# Altium schematic sheet Core.SchDoc
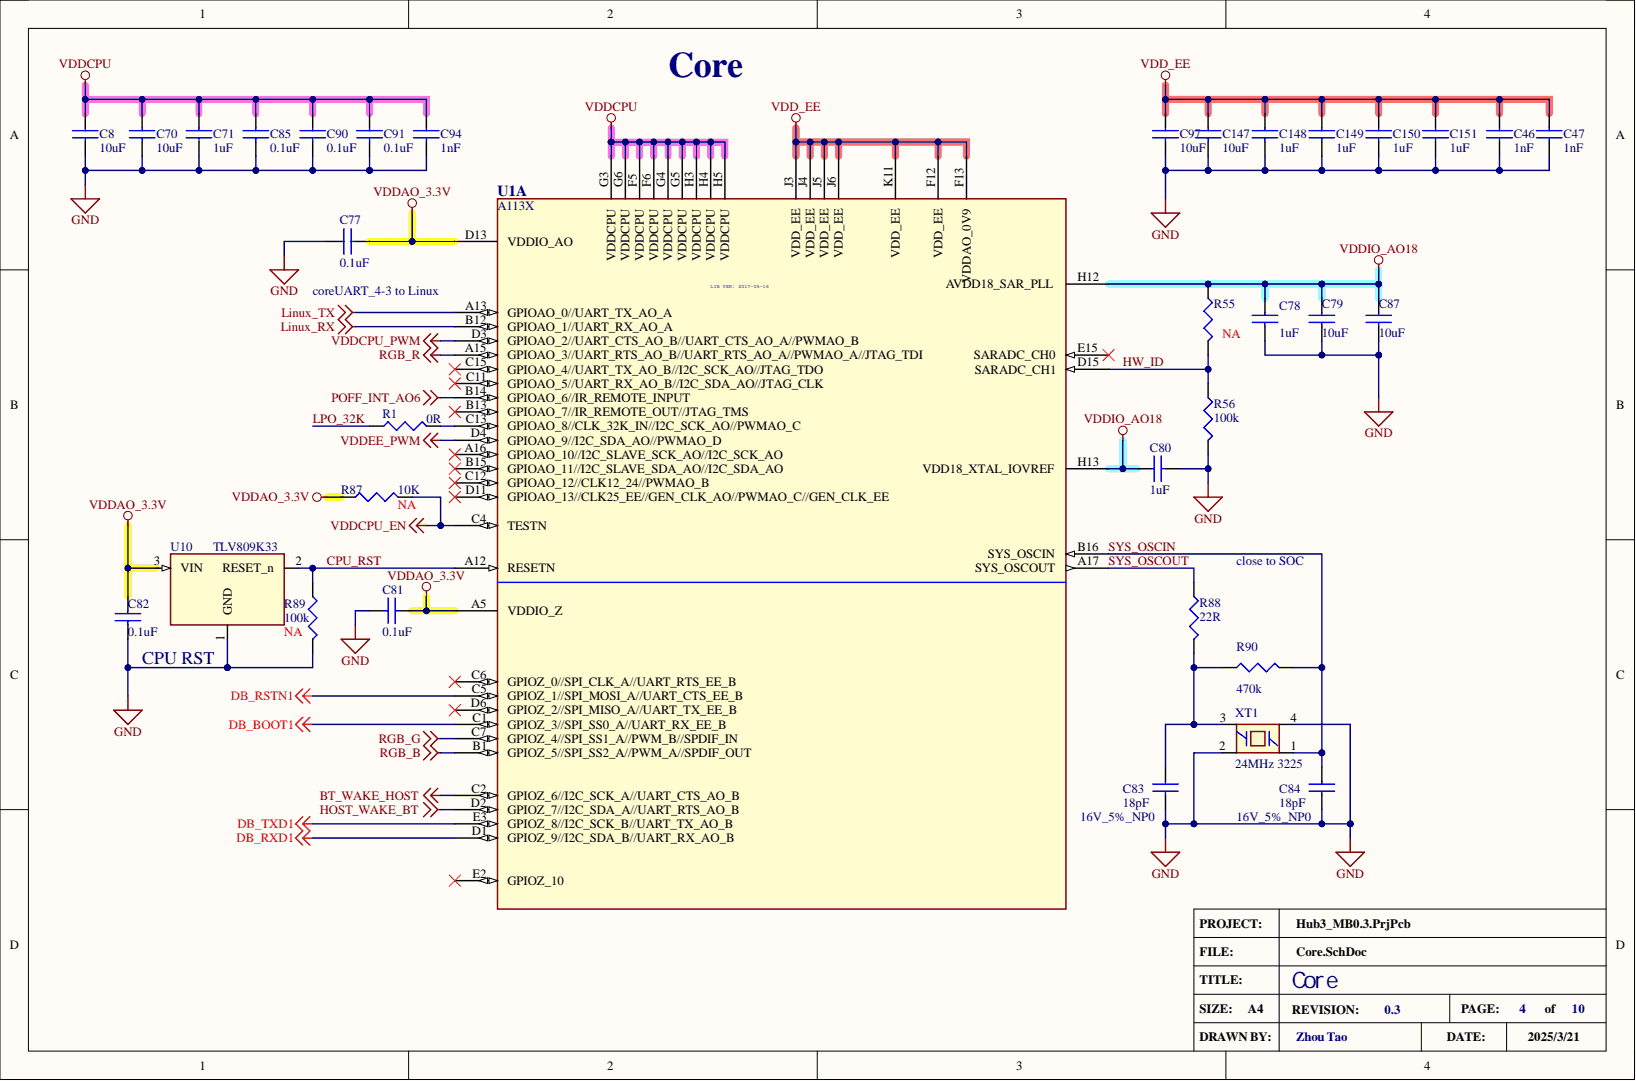
[diagram p0: Core.SchDoc]

## Parts
C8  10uF  [C0603]
C46  1nF  [C0201]
C47  1nF  [C0201]
C70  10uF  [C0603]
C71  1uF  [C0201]
C77  0.1uF  [C0201]
C78  1uF  [C0201]
C79  10uF  [C0603]
C80  1uF  [C0201]
C81  0.1uF  [C0201]
C82  0.1uF  [C0201]
C83  18pF  [C0402]
C84  18pF  [C0402]
C85  0.1uF  [C0201]
C87  10uF  [C0603]
C90  0.1uF  [C0201]
C91  0.1uF  [C0201]
C94  1nF  [C0201]
C97  10uF  [C0603]
C147  10uF  [C0603]
C148  1uF  [C0201]
C149  1uF  [C0201]
C150  1uF  [C0201]
C151  1uF  [C0201]
R1  0R  [R0201]
R55  NA  [R0402]  (no BOM)
R56  100k  [R0402]
R87  NA  value 10K  [R0201]  (no BOM)
R88  22R  [R0201]
R89  NA  value 100k  [R0402]  (no BOM)
R90  value 470k  [R0402]  (hidden comment: RES_470k_0402_1%)
U1  A113X  [A113X_284]  (part A)
U10  TLV809K33  [SOT-23]
XT1  24MHz 3225  [XT-SMD4P3225]

## Nets
BT_WAKE_HOST: U1.C2(GPIOZ_6//I2C_SCK_A//UART_CTS_AO_B)
DB_BOOT1: U1.C1(GPIOZ_3//SPI_SS0_A//UART_RX_EE_B)
DB_RSTN1: U1.C5(GPIOZ_1//SPI_MOSI_A//UART_CTS_EE_B)
DB_RXD1: U1.D1(GPIOZ_9//I2C_SDA_B//UART_RX_AO_B)
DB_TXD1: U1.E3(GPIOZ_8//I2C_SCK_B//UART_TX_AO_B)
GND: C8.2 C46.2 C47.2 C70.2 C71.2 C77.1 C78.2 C79.2 C80.2 C81.2 C82.2 C83.2 C84.2 C85.2 C87.2 C90.2 C91.2 C94.2 C97.2 C147.2 C148.2 C149.2 C150.2 C151.2 R56.2 R89.2 U10.1 XT1.2 XT1.4
HOST_WAKE_BT: U1.D2(GPIOZ_7//I2C_SDA_A//UART_RTS_AO_B)
Linux_RX: U1.B12(GPIOAO_1//UART_RX_AO_A)
Linux_TX: U1.A13(GPIOAO_0//UART_TX_AO_A)
POFF_INT_AO6: U1.B14(GPIOAO_6//IR_REMOTE_INPUT)
RGB_B: U1.B1(GPIOZ_5//SPI_SS2_A//PWM_A//SPDIF_OUT)
RGB_G: U1.C7(GPIOZ_4//SPI_SS1_A//PWM_B//SPDIF_IN)
RGB_R: U1.A15(GPIOAO_3//UART_RTS_AO_B//UART_RTS_AO_A//PWMAO_A//JTAG_TDI)
VDD_EE: C46.1 C47.1 C97.1 C147.1 C148.1 C149.1 C150.1 C151.1 U1.F12(VDD_EE) U1.F13(VDDAO_0V9) U1.J3(VDD_EE) U1.J4(VDD_EE) U1.J5(VDD_EE) U1.J6(VDD_EE) U1.K11(VDD_EE)
VDDAO_3.3V: C77.2 C81.1 C82.1 R87.1 U1.A5(VDDIO_Z) U1.D13(VDDIO_AO) U10.3
VDDCPU: C8.1 C70.1 C71.1 C85.1 C90.1 C91.1 C94.1 U1.F5(VDDCPU) U1.F6(VDDCPU) U1.G3(VDDCPU) U1.G4(VDDCPU) U1.G5(VDDCPU) U1.G6(VDDCPU) U1.H3(VDDCPU) U1.H4(VDDCPU) U1.H5(VDDCPU)
VDDCPU_EN: R87.2 U1.C4(TESTN)
VDDCPU_PWM: U1.D3(GPIOAO_2//UART_CTS_AO_B//UART_CTS_AO_A//PWMAO_B)
VDDEE_PWM: U1.D4(GPIOAO_9//I2C_SDA_AO//PWMAO_D)
VDDIO_AO18: C78.1 C79.1 C80.1 C87.1 R55.1 U1.H12(AVDD18_SAR_PLL) U1.H13(VDD18_XTAL_IOVREF)
CPU_RST: R89.1 U1.A12(RESETN) U10.2
HW_ID: R55.2 R56.1 U1.D15(SARADC_CH1)
LPO_32K: R1.2
SYS_OSCIN: C84.1 R90.2 U1.B16(SYS_OSCIN) XT1.1
SYS_OSCOUT: R88.1 U1.A17(SYS_OSCOUT)
NetC83_1: C83.1 R88.2 R90.1 XT1.3
NetR1_1: R1.1 U1.C13(GPIOAO_8//CLK_32K_IN//I2C_SCK_AO//PWMAO_C)

Not connected: U1 A16(GPIOAO_10//I2C_SLAVE_SCK_AO//I2C_SCK_AO) B13(GPIOAO_7//IR_REMOTE_OUT//JTAG_TMS) B15(GPIOAO_11//I2C_SLAVE_SDA_AO//I2C_SDA_AO) C6(GPIOZ_0//SPI_CLK_A//UART_RTS_EE_B) C11(GPIOAO_5//UART_RX_AO_B//I2C_SDA_AO//JTAG_CLK) C12(GPIOAO_12//CLK12_24//PWMAO_B) C15(GPIOAO_4//UART_TX_AO_B//I2C_SCK_AO//JTAG_TDO) D6(GPIOZ_2//SPI_MISO_A//UART_TX_EE_B) D11(GPIOAO_13//CLK25_EE//GEN_CLK_AO//PWMAO_C//GEN_CLK_EE) E2(GPIOZ_10) E15(SARADC_CH0)

## Library data of the parts
C8 C70 C79 C87 C97 C147 = Cap_10uF_0603_10%_10V: Manufacturer: Samsung; Part Number: CL10A106KP8NNNC; Des: 10uF,0603,X5R, 10%, 10V; Component Kind: Standard; value: 10uF; Library Name: Lib_sch_Hubv3_2332.SchLib
C46 C47 C94 = Cap_1nF_0201_50V: Manufacturer: EYANG; Part Number: C0201X7R102K500NTA; Des: 1nF,0201,50V,X7R,10%; Component Kind: Standard; value: 1nF; Library Name: Lib_sch_Hubv3_2332.SchLib
C71 C78 C80 C148-C151 = Cap_1uF_0201_6.3V: Manufacturer: Walsin Tech; Part Number: 0201X105K6R3CT; Des: 1uF,0201,6.3V,10%,X5R; Component Kind: Standard; value: 1uF; Library Name: Lib_sch_Hubv3_2332.SchLib
C77 C81 C82 C85 C90 C91 = Cap_0.1uF_0201_16V: Manufacturer: EYANG; Part Number: C0201X5R104K160NTA; Des: 0.1uF,0201,16V,X5R,10%; Component Kind: Standard; value: 0.1uF; Library Name: Lib_sch_Hubv3_2332.SchLib
C83 C84 = Cap_18pF_0402_16V_5%: Manufacturer: KEMET; Part Number: C0402C180J4GAC7867; Des: 18pF,0402,16V,5%,NP0,low ESL; Component Kind: Standard; value: 18pF; Library Name: Lib_sch_spk_V0_180613.SchLib
R1 = RES_0R_0201: Manufacturer: Yageo; part number: RC0201JR-070RL; Des: 0R,0201,1/20w; Component Kind: Standard; value: 0R; Library Name: Lib_sch_spk_V1_2020604.SchLib
R55 = RES_0402_NA: value: NA; Library Name: Lib_sch_spk_V1_2020604.SchLib
R56 R89 = RES_100k_0402_1%: Manufacturer: Yageo; part number: RC0402FR-07100KL; Des: 100k,0402,1%,1/16w
  R56: Component Kind: Standard; value: 100k; Library Name: Lib_sch_spk_V1_2020604.SchLib
  R89: Library Name: Lib_sch_spk_V0_180613.SchLib
R87 = RES_10K_0201: Manufacturer: Yageo; part number: RC0201FR-0710KP; Des: 10K,0201,1/20w; Library Name: Lib_sch_Hubv3_2332.SchLib
R88 = RES_22R_0201_1%: Manufacturer: Yageo; part number: RC0201FR-0722RL; Des: 22R,0201,1%,1/20w; Component Kind: Standard; value: 22R; Library Name: Lib_sch_spk_V1_2020604.SchLib
R90 = RES_470k_0402_1%: Manufacturer: Yageo; part number: RC0402FR-07470KL; Des: 470k,0402,1%,1/16w; Component Kind: Standard; Library Name: Lib_sch_spk_V0_180613.SchLib
U1 = A113X_20170516_V17: Manufacturer: AmLogic; Des: A113X, BGA,V17; Component Kind: Standard; Part Number: A113X; value: A113X; Library Name: Lib_sch_Hubv3_2332.SchLib
U10 = TLV809K33_reset_SOT23: Manufacturer: TI; Part Number: TLV809K33DBVR; Des: Reset IC, SOT-23, 3.3V threshold; Component Kind: Standard; value: TLV809K33; Library Name: Lib_sch_Hubv3_2332.SchLib
XT1 = Crystal_SMD_24MHz_3225: Manufacturer: TST; Part Number: TZ2441C; Des: Crystal,24MHz,CL12pF,10ppm,3.2*2.5mm; Component Kind: Standard; value: 24MHz 3225; Library Name: Lib_sch_spk_V1_2020604.SchLib
